# Altium schematic sheet CPU.SchDoc
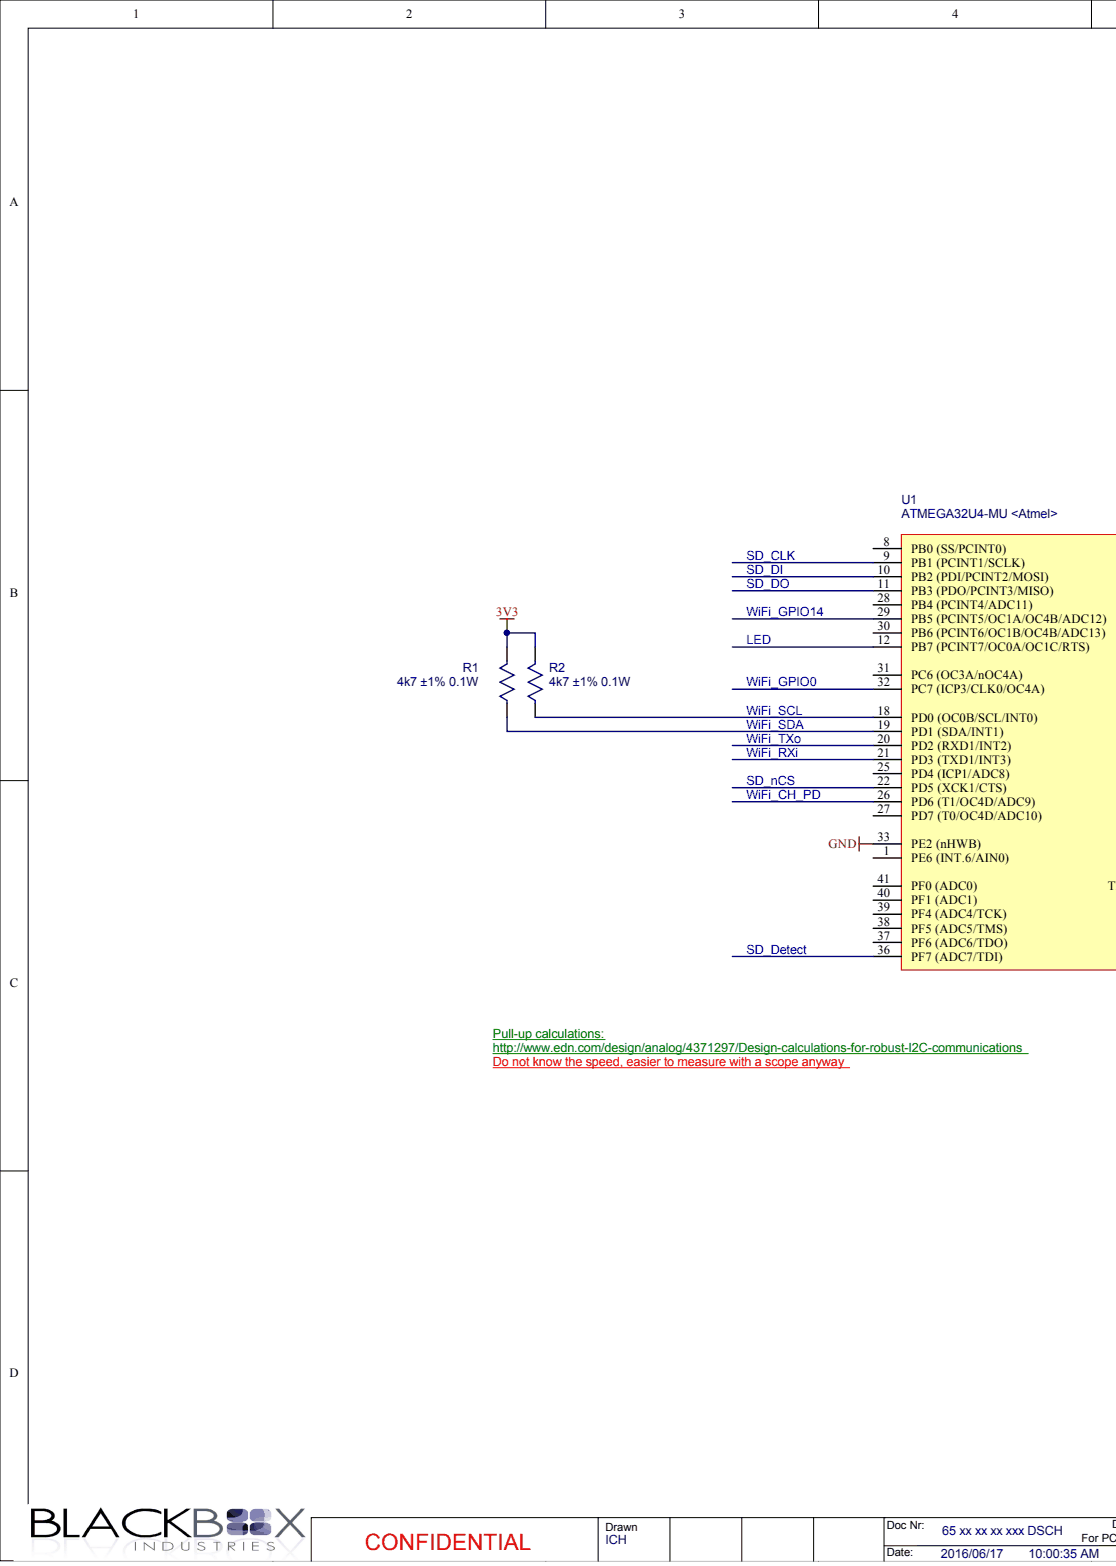
[diagram p0: CPU.SchDoc, left]
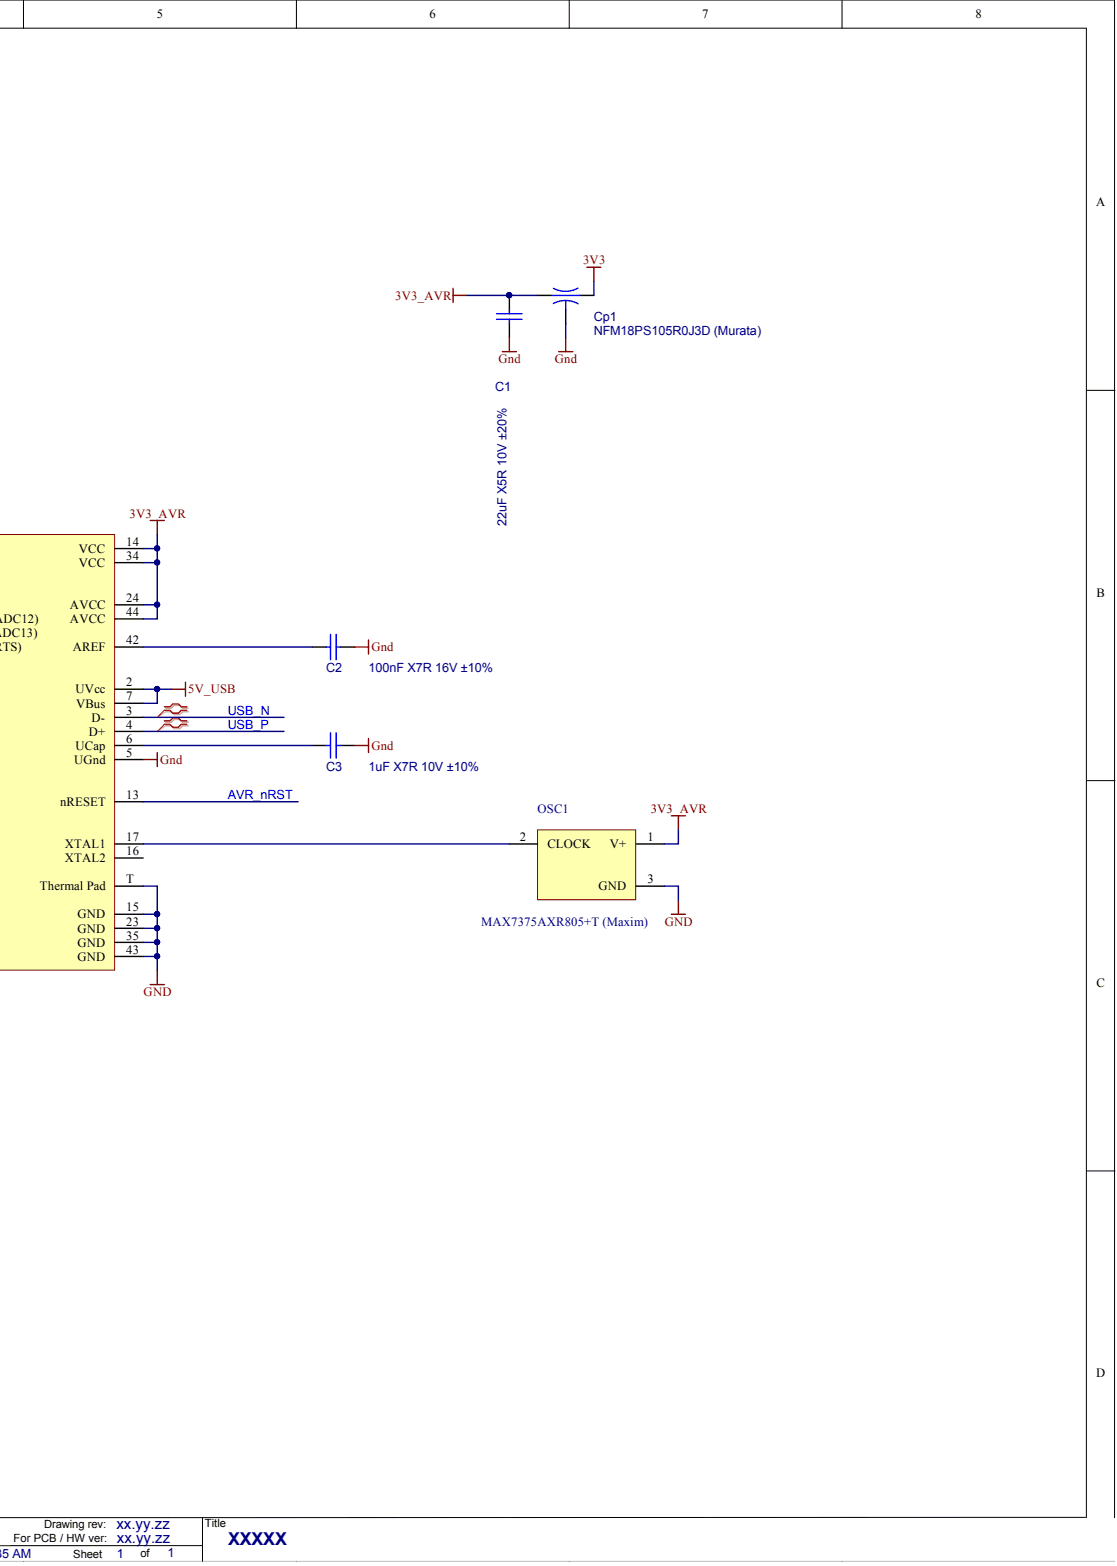
[diagram p1: CPU.SchDoc, right]

## Parts
C1  22uF X5R 10V ±20%  [0603_CAP]
C2  100nF X7R 16V ±10%  [0603_CAP]
C3  1uF X7R 10V ±10%  [0603_CAP]
Cp1  NFM18PS105R0J3D (Murata)  [NFM18PS (Murata)]
OSC1  MAX7375AXR805+T (Maxim)  [SC70-3 <Ti>]
R1  4k7 ±1% 0.1W  [0603_RES]
R2  4k7 ±1% 0.1W  [0603_RES]
U1  ATMEGA32U4-MU <Atmel>  [QFN7x7- 44+T]

## Nets
3V3: Cp1.2 R1.2 R2.2
3V3_AVR: C1.1 Cp1.1 OSC1.1 U1.14(VCC) U1.24(AVCC) U1.34(VCC) U1.44(AVCC)
5V_USB: U1.2(UVcc) U1.7(VBus)
GND: C1.2 C2.2 C3.2 Cp1.3 OSC1.3 U1.5(UGnd) U1.15(GND) U1.23(GND) U1.33(PE2_(nHWB)) U1.35(GND) U1.43(GND) U1.T(Thermal_Pad)
AVR_NRST: U1.13(nRESET)
LED: U1.12(PB7_(PCINT7/OC0A/OC1C/RTS))
SD_CLK: U1.9(PB1_(PCINT1/SCLK))
SD_DETECT: U1.36(PF7_(ADC7/TDI))
SD_DI: U1.10(PB2_(PDI/PCINT2/MOSI))
SD_DO: U1.11(PB3_(PDO/PCINT3/MISO))
SD_NCS: U1.22(PD5_(XCK1/CTS))
USB_N: U1.3(D-)
USB_P: U1.4(D+)
WIFI_CH_PD: U1.26(PD6_(T1/OC4D/ADC9))
WIFI_GPIO0: U1.32(PC7_(ICP3/CLK0/OC4A))
WIFI_GPIO14: U1.29(PB5_(PCINT5/OC1A/OC4B/ADC12))
WIFI_RXI: U1.21(PD3_(TXD1/INT3))
WIFI_SCL: R2.1 U1.18(PD0_(OC0B/SCL/INT0))
WIFI_SDA: R1.1 U1.19(PD1_(SDA/INT1))
WIFI_TXO: U1.20(PD2_(RXD1/INT2))
NetC2_1: C2.1 U1.42(AREF)
NetC3_1: C3.1 U1.6(UCap)
NetOSC1_2: OSC1.2 U1.17(XTAL1)

Not connected: U1 1(PE6_(INT.6/AIN0)) 8(PB0_(SS/PCINT0)) 16(XTAL2) 25(PD4_(ICP1/ADC8)) 27(PD7_(T0/OC4D/ADC10)) 28(PB4_(PCINT4/ADC11)) 30(PB6_(PCINT6/OC1B/OC4B/ADC13)) 31(PC6_(OC3A/nOC4A)) 37(PF6_(ADC6/TDO)) 38(PF5_(ADC5/TMS)) 39(PF4_(ADC4/TCK)) 40(PF1_(ADC1)) 41(PF0_(ADC0))

## Library data of the parts
C1 = 22uF X5R 10V ±20%: Capacitor, ceramic 0603; Component Kind: Standard; descr: Capacitor, ceramic 0603; Supplier 1: Digi-Key; Supplier Part Number 1: 445-9077-1-ND; Library Name: Onecell Ig.IntLib
C2 = 100nF X7R 16V ±10%: Capacitor, ceramic; Component Kind: Standard; descr: Capacitor, ceramic; Library Name: OneCell passives.IntLib; Supplier 1: Digi-Key; Supplier Part Number 1: 490-1532-2-ND
C3 = 1uF X7R 10V ±10%: Capacitor, ceramic; Component Kind: Standard; descr: Capacitor, ceramic; Library Name: OneCell passives.IntLib; Supplier 1: Digi-Key; Supplier Part Number 1: 587-1242-2-ND
Cp1 = NFM18PS105R0J3D (Murata): Passthrough cap 1uF 2A 6.3V; ClassName: PassThroughCaps; Component Kind: Standard; descr: Passthrough cap 1uF 2A 6.3V; Supplier 1: Digi-Key; Supplier Part Number 1: 490-6974-1-ND; Library Name: Onecell Ig.IntLib
OSC1 = MAX7375AXR805+T (Maxim): Osc MEMS 8MHz; Component Kind: Standard; descr: Osc MEMS 8MHz; Supplier 1: Digi-Key; Supplier Part Number 1: MAX7375AXR805+TCT-ND; Library Name: Onecell Ig.IntLib
R1 R2 = 4k7 ±1% 0.1W: resistor, thickfilm; Component Kind: Standard; descr: resistor, thickfilm; Library Name: OneCell passives.IntLib; Supplier 1: Digi-Key; Supplier Part Number 1: 1276-3502-2-ND
U1 = ATMEGA32U4-MU <Atmel>: IC AVR QFN44; Component Kind: Standard; descr: IC AVR QFN44; Supplier 1: Digi-Key; Supplier Part Number 1: ATMEGA32U4-MU-ND; Library Name: Onecell Ig.IntLib
